# Altium schematic sheet RobotPower.SchDoc
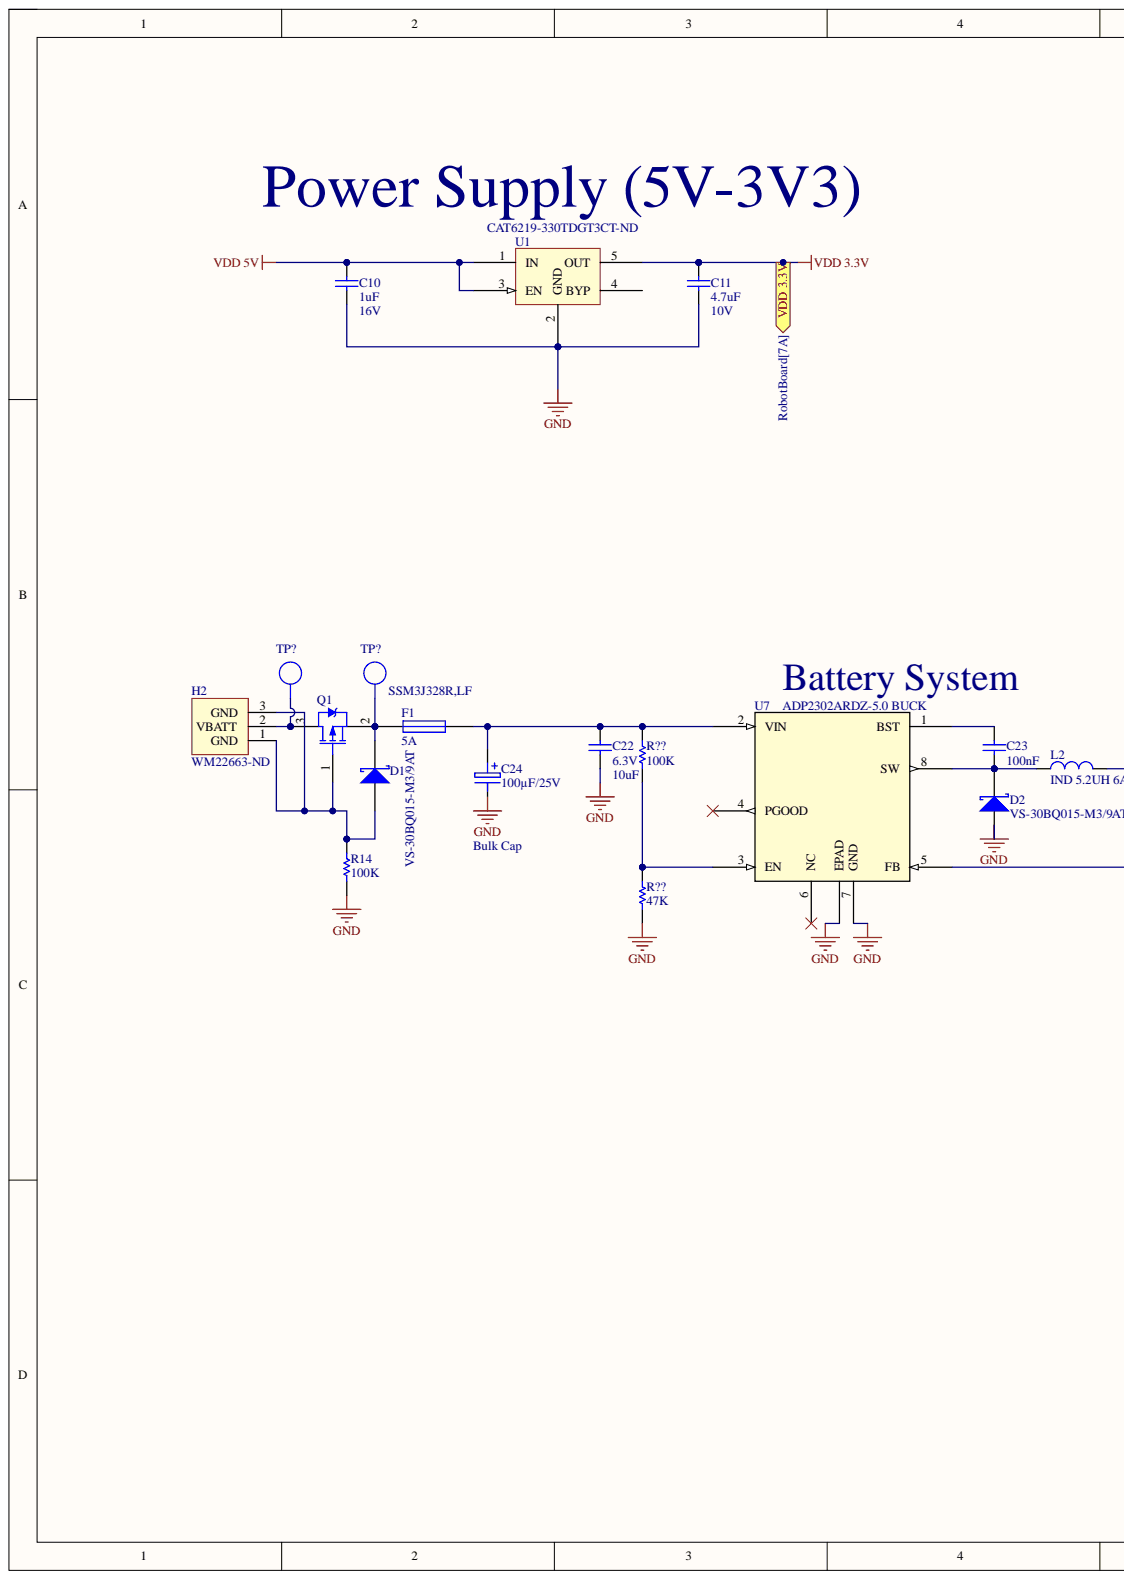
[diagram p0: RobotPower.SchDoc, left]
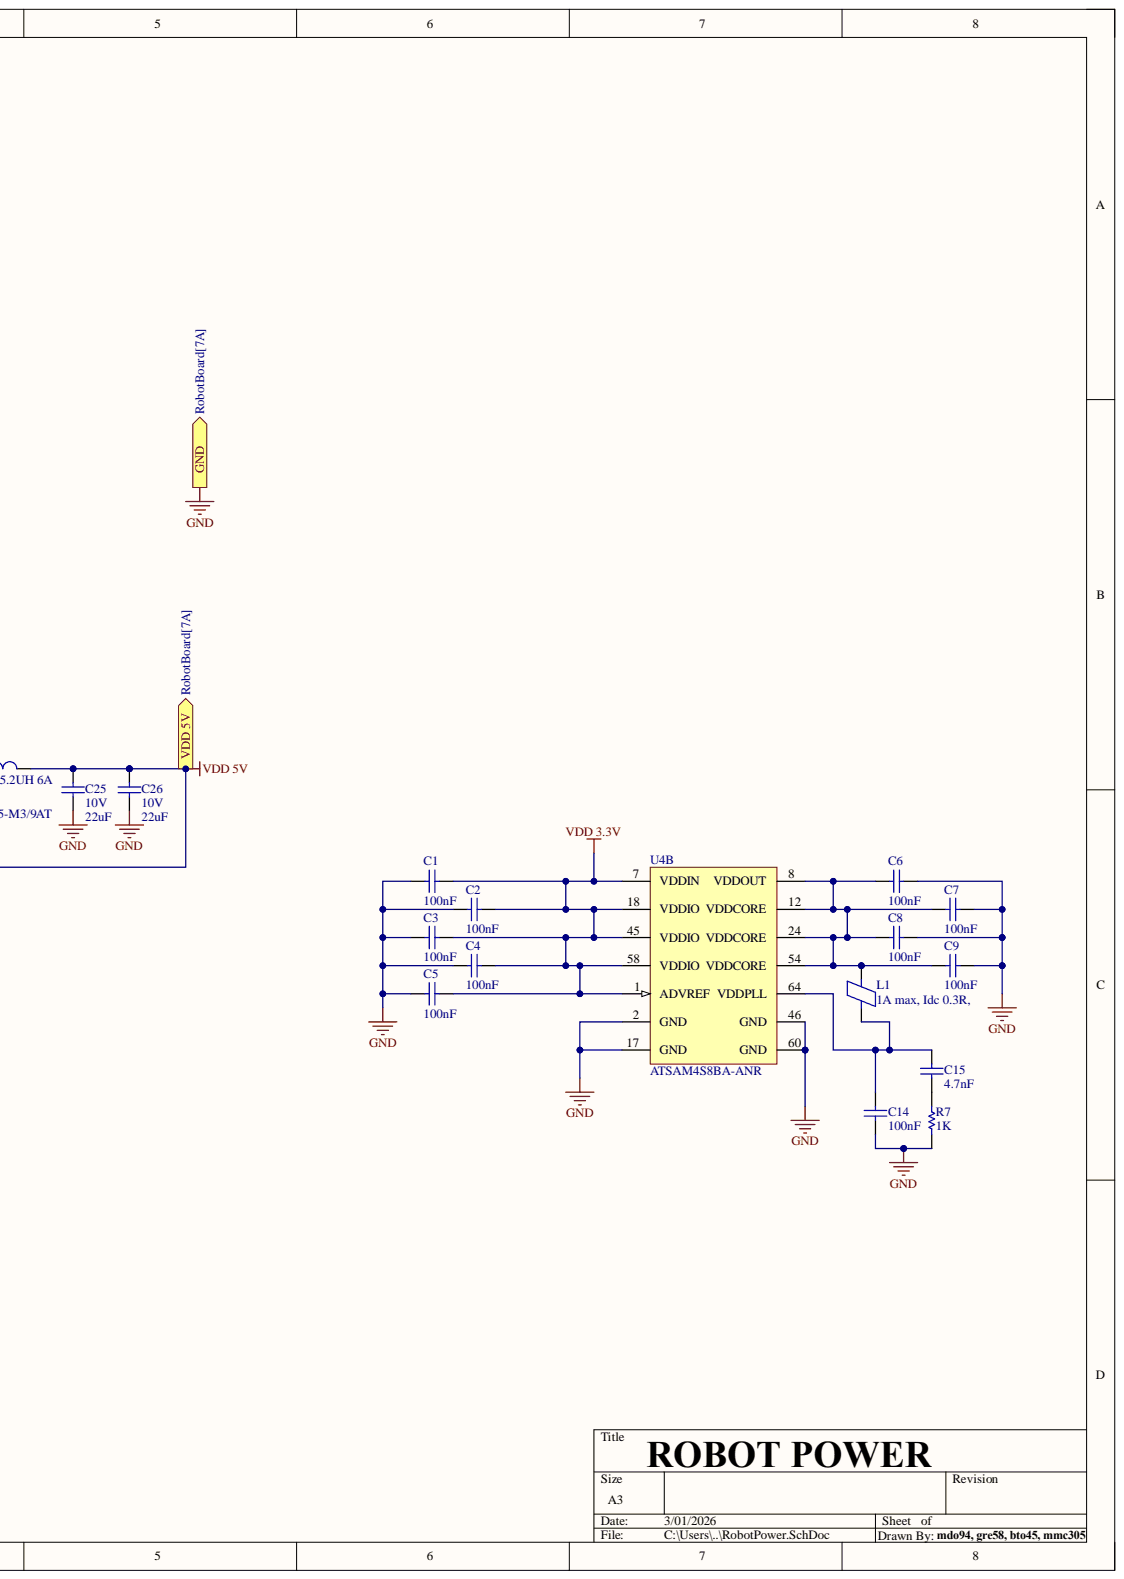
[diagram p1: RobotPower.SchDoc, right]

## Parts
C1  100nF  [CAPC1608L]
C2  100nF  [CAPC1608L]
C3  100nF  [CAPC1608L]
C4  100nF  [CAPC1608L]
C5  100nF  [CAPC1608L]
C6  100nF  [CAPC1608L]
C7  100nF  [CAPC1608L]
C8  100nF  [CAPC1608L]
C9  100nF  [CAPC1608L]
C10  1uF  Max Voltage: 16V  [CAPC1608L]
C11  4.7uF  Max Voltage: 10V  [CAPC1608N]
C14  100nF  [CAPC1608L]
C15  4.7nF  [CAPC1608L]
C22  10uF  Voltage - Rated: 6.3V  [CAPC1608L]
C23  100nF  [CAPC1608L]
C24  100µF/25V  [CAPAE6666X77M]
C25  22uF  Max Voltage: 10V  [1206 (3216 metric)]
C26  22uF  Max Voltage: 10V  [1206 (3216 metric)]
D1  VS-30BQ015-M3/9AT  [SMC]
D2  VS-30BQ015-M3/9AT  [SMC]
F1  5A  [RESC3216N]
H2  Supplier Part Number 1: WM22663-ND  [BATT_HEADER_3POS]  (hidden comment: Battery_HEADER_3pin)
L1  1A max, Idc 0.3R,  [INDC3216L]
L2  IND 5.2UH 6A  [INDUCTOR5u2]
Q1  SSM3J328R,LF  [SOT23_L]
R7  1K  [RESC1608L]
R14  100K  [RESC1608L]
R??  100K  [RESC1608L]
R??  47K  [RESC1608N]
TP?  TP  [TP_1206]  (no BOM)
TP?  TP  [TP_1206]  (no BOM)
U1  CAT6219-330TDGT3  Supplier Part Number: CAT6219-330TDGT3CT-ND  [SOT95P280X145-5N]
U4  ATSAM4S8BA-ANR  [LQFP64_L]  (part B)
U7  ADP2302ARDZ-5.0 BUCK  [RD_8_1]

## Ports
GND, VDD 3.3V, VDD 5V

## Nets
GND: C1.1 C2.1 C3.1 C4.1 C5.1 C6.2 C7.2 C8.2 C9.2 C10.2 C11.2 C14.2 C22.2 C24.1 C25.2 C26.2 D2.1 R7.2 R14.2 R??.2 U1.2 U4.2 U4.17 U4.46 U4.60 U7.7 U7.EPAD ; port GND
VDD 3.3V: C1.2 C2.2 C3.2 C4.2 C5.2 C11.1 U1.5 U4.1 U4.7 U4.18 U4.45 U4.58 ; port VDD 3.3V
VDD 5V: C10.1 C25.1 C26.1 L2.2 U1.1 U1.3 U7.5 ; port VDD 5V
NetC6_1: C6.1 C7.1 C8.1 C9.1 L1.1 U4.8 U4.12 U4.24 U4.54
NetC14_1: C14.1 C15.1 L1.2 U4.64
NetC15_2: C15.2 R7.1
NetC22_1: C22.1 C24.2 F1.2 R??.1 U7.2
NetC23_1: C23.1 U7.1
NetC23_2: C23.2 D2.2 L2.1 U7.8
NetD1_1: D1.1 H2.1 H2.3 Q1.1 R14.1
NetD1_2: D1.2 F1.1 Q1.2 TP?.1
NetH2_2: H2.2 Q1.3 TP?.1
NetR??_1: R??.1 R??.2 U7.3

Not connected: U1 4; U7 4 6

## Library data of the parts
C1-C9 C14 C23 = CC_100nF_0603: CAP CER 100nF X7R +-10% 50V 0603; Code_IPC: 1608 [0603]; Component Kind: Standard; Library Name: ECE_ComponentsAD23_2.IntLib; PackageReference: 0603; Signal Integrity: C0603; Simulation: CAP; Storage Location: PCB Assembly WS; Supplier: ECE Dept; Value: 100nF
C10 = CC_1uF_0603: CAP CER 1uF Y5V -20% +80% 16V 0603; Code_IPC: 1608 [0603]; Component Kind: Standard; Library Name: ECE_ComponentsAD23_2.IntLib; PackageReference: 0603; Signal Integrity: C0603; Simulation: CAP; Storage Location: PCB Assembly WS; Supplier: ECE Dept; Value: 1uF
C11 = CC_4.7uF_0603: CAP CER 4.7uF X5R +-20%  10V 0603; Note: Footprint corresponds to dimensions for bulk cassette packaging. This case size has tightened tolerances.; Code_IPC: 2012[0805]; Component Kind: Standard; Library Name: ECE_ComponentsAD23_2.IntLib; PackageReference: 0805; Simulation: CAP; Storage Location: PCB Assembly WS; Supplier: ECE Dept; Value: 4.7uF
C15 = CC_4.7nF_0603: CAP CER 4.7nF X7R +-10% 50V 0603; Code_IPC: 1608 [0603]; Component Kind: Standard; Library Name: ECE_ComponentsAD23_2.IntLib; PackageReference: 0603; Signal Integrity: C0603; Simulation: CAP; Storage Location: PCB Assembly WS; Supplier: ECE Dept; Value: 4.7nF
C22 = CC_10uF_0603: CAP CER 10UF X5R +-20% 6V3 0603; Component Kind: Standard; Library Name: ECE_ComponentsAD23_2.IntLib; Signal Integrity: C0805; Simulation: CAP; Storage Location: PCB Assembly WS; Supplier: ECE Dept; Value: 10uF
C24 = CA_100uF_25V: CAP ALUM 100UF 25V 20% SMD; Manufacturer: Nichicon; Manufacturer Part Number: UWT1E101MCL1GS; Ripple Current: 91mA; Capacitance: 100µF/25V; Component Kind: Standard; Height - Seated (Max): 0.303" (7.70mm); Library Name: ECE_ComponentsAD23_2.IntLib; Mounting Type: Surface Mount; Operating Temperature: -55°C ~ 105°C; Package / Case: Radial, Can - SMD; Size / Dimension: 0.248" Dia (6.30mm); Supplier 1: Digi-Key; Supplier Part Number 1: 493-2190-1-ND
C25 C26 = CC_22uF_1206: CAP CER 22uF Y5V -20% +80% 10V 1206; Component Kind: Standard; Dielectric: Y5V; Library Name: ECE_ComponentsAD23_2.IntLib; Simulation: CAP; Storage Location: PCB Assembly WS; Supplier: ECE Dept; Value: 22uF
D1 D2 = DS_VS-30BQ015-M3: DIODE, SCHOTTKY, 3A, 15V, DO-214AB / SMC; Manufacturer: Vishay Semiconductors; Forward Voltage: 350mV; Max Forward Surge Current (Ifsm): 650A; Peak Reverse Current: 4mA; Average Rectified Current: 3A; Component Kind: Standard; ComponentLink1Description: https://datasheet.ciiva.com/36181/vs-30bq015-m3-9at-36181258.pdf; ComponentLink1URL: https://datasheet.ciiva.com/36181/vs-30bq015-m3-9at-36181258.pdf; Library Name: ECE_ComponentsAD23_2.IntLib; Manufacturer Part Number: VS-30BQ015-M3/9AT; Max Reverse Voltage (DC): 15V; Supplier: Digi-Key; Supplier 1: Digi-Key; Supplier 2: Element14; Supplier Part Number: VS-30BQ015-M3/9AT-ND; Supplier Part Number 1: VS-30BQ015-M3/9ATGIDKR-ND; Supplier Part Number 2: 2628288
F1 = FS_Fuse_5.0A: Fuse 5.0A Fast Acting 1206 SMD; Manufacturer: Bel TRP; Manufacturer Part Number: C1F5; Approvals: CE,cURus; DC Cold Resistance: 19mR; Fuse Type: Board Mount (Cartridge Style Excluded); Voltage Rating: 125V; Voltage Rating (DC): 63V; Case Code (Imperial): 1206; Case Code (Metric): 3216; Case/Package: 1206; Component Kind: Standard; ComponentLink1Description: http://www.farnell.com/datasheets/2571625.pdf; ComponentLink1URL: http://www.farnell.com/datasheets/2571625.pdf; ComponentLink2Description: http://belfuse.com/pdfs/C1F.pdf; ComponentLink2URL: http://belfuse.com/pdfs/C1F.pdf; ComponentLink3Description: http://www.mouser.com/ds/2/643/C1F-594910.pdf; ComponentLink3URL: http://www.mouser.com/ds/2/643/C1F-594910.pdf; ComponentLink4Description: https://belfuse.com/resources/StewartConnector/C1F.pdf; ComponentLink4URL: https://belfuse.com/resources/StewartConnector/C1F.pdf; Contact Plating: Nickel,Tin; Current Rating: 5A; Length: 0.126inch; Library Name: ECE_ComponentsAD23_2.IntLib; Max Operating Temperature: 125°C; Min Operating Temperature: -55°C; Mount: Surface Mount; Number of Pins: 2; Packaging: Tape and Reel; Pricing 1: 1=0.32, 5=0.312, 10=0.298, 50=0.2662, 100=0.2479, 250=0.22032, 500=0.1836, 1000=0.17442 (USD); Stock 1: 725; Supplier 1: Digi-Key; Supplier 2: Element14; Supplier Part Number 1: 507-1886-1-ND; Supplier Part Number 2: 2848595; Value: 5A; Voltage Rating (AC): 125V; Width: 0.062inch
H2 = Battery_HEADER_3pin: 3Pin Header 2.54mm spacing  Digikey #WM22663-ND; Component Kind: Standard; Library Name: ENCE461AD23.IntLib; Supplier 1: Digi-Key
L1 = IN_Ferrite-Bead: Ferrite Bead, Rdc 0.3R, Z100MHZ 600R, Imax 1A, SMD 1206; Manufacturer: FAIR-RITE; Manufacturer Part Number: 2512066017Y1; DC Current Rating: 1; DC Resistance Max: 0.08; Ferrite Case Style: 1206 ^3216 Metric]; Frequency Range: 50MHz to 1; Impedance: 600; Impedance Tolerance: ± 25%; Component Kind: Standard; Ferrite Mounting: SMD; Library Name: ECE_ComponentsAD23_2.IntLib; Manufacturer PN: 2512066017Y1; Signal Integrity: Inductor Isolated; Storage Location: PCB Assembly WS; Supplier: ECE Dept; Supplier 1: Farnell; Supplier Part Number 1: 1612060; Value: 1A max, Idc 0.3R,
L2 = CDRH104RNP-5R2NC: FIXED IND 5.2UH 6A 22 MOHM SMD; Manufacturer: Sumida America Components Inc.; Current - Saturation: 5.6A; DC Resistance (DCR): 22 mOhm Max; Material - Core: Ferrite; Shielding: Shielded; Tolerance: ±30%; Category: Inductors, Coils, Chokes; Component Kind: Standard; ComponentLink1Description: http://products.sumida.com/products/pdf/CDRH104R.pdf; ComponentLink1URL: http://products.sumida.com/products/pdf/CDRH104R.pdf; Frequency - Self Resonant: -; Height - Seated (Max): 0.157" (4.00mm); Library Name: ENCE461AD23.IntLib; Manufacturer Part Number: CDRH104RNP-5R2NC; Mounting Type: Surface Mount; Operating Temperature: -40°C ~ 100°C; Package / Case: Nonstandard; Packaging: Cut Tape (CT); Part Status: Active; Pricing 1: 1=2.9, 10=2.678, 100=1.8743, 500=1.5173 (NZD); Q @ Freq: -; Ratings: -; RoHS: RoHS Compliant; Size / Dimension: 0.402" L x 0.394" W (10.20mm x 10.00mm); Stock 1: 3849; Supplier 1: Digi-Key; Supplier Device Package: -; Supplier Part Number 1: 308-2280-1-ND; Type: -
Q1 = TM_SSM3J328R: MOSFET P-CH 20V 6A SOT23-3; Manufacturer: Toshiba; Drain to Source Breakdown Voltage: -20V; Drain to Source Resistance: 47.4mR; Element Configuration: Single; FET Feature: Logic Level Gate, 1.5V Drive; FET Type: MOSFET P-Channel, Metal Oxide; Gate Charge (Qg) @ Vgs: 12.8nC @ 4.5V; Gate to Source Voltage (Vgs): 8V; Input Capacitance: 840pF; Input Capacitance (Ciss) @ Vds: 840pF @ 10V; Max Power Dissipation: 1W; Number of Channels: 1; Power Dissipation: 2W; Rds On Max: 29.8mR; Turn-Off Delay Time: 107ns; Turn-On Delay Time: 32ns; Vgs(th) (Max) @ Id: 1V @ 1mA; Case/Package: SOT-23-3; Component Kind: Standard; ComponentLink1Description: https://datasheet.ciiva.com/11158/ssm3j328r-datasheet-en-20140301-11158652.pdf; ComponentLink1URL: https://datasheet.ciiva.com/11158/ssm3j328r-datasheet-en-20140301-11158652.pdf; Continuous Drain Current (ID): 6A; Current - Continuous Drain (Id) @ 25° C: 6A; Drain to Source Voltage (Vdss): 20V; Library Name: ECE_ComponentsAD23_2.IntLib; Manufacturer Part Number: SSM3J328R,LF; Max Operating Temperature: 150°C; Min Operating Temperature: -55°C; Mount: Surface Mount; Number of Elements: 1; Number of Pins: 3; Package / Case: SOT-23-3 Flat Leads; Package Quantity: 3000; Packaging: Tape and Reel; Power - Max: 1W; Pricing: 1=0.79, 10=0.658, 25=0.5772, 100=0.4939, 250=0.42836, 500=0.36284, 1000=0.27972 (NZD); Radiation Hardening: No; Storage Location: PCB Assembly WS; Supplier: Digi-Key; Supplier 1: Digi-Key; Supplier Device Package: SOT-23F; Supplier Part Number: SSM3J328RLFDKR-ND; Supplier Part Number 1: SSM3J328RLFDKR-ND
R7 = RS_1K: RES 1.0K OHM 1/10W 5% 0603 SMD; Component Kind: Standard; Library Name: ECE_ComponentsAD23_2.IntLib; Signal Integrity: Res; Simulation: RESISTOR; Storage Location: PCB Assembly WS; Supplier: ECE Dept; Value: 1K
R14 R?? = RS_100K: RES 100K OHM 1/10W 5% 0603 SMD; Component Kind: Standard; Library Name: ECE_ComponentsAD23_2.IntLib; Signal Integrity: Res; Simulation: RESISTOR; Storage Location: PCB Assembly WS; Supplier: ECE Dept; Value: 100K
R?? = RS_47K: RES 47.0K OHM 1/10W 5% 0603 SMD; Component Kind: Standard; Library Name: ECE_ComponentsAD23_2.IntLib; Signal Integrity: Res; Simulation: RESISTOR; Storage Location: PCB Assembly WS; Supplier: ECE Dept; Value: 47K
TP? TP? = TP_1206: Test-Point 3.3x1.6x2.1mm SMD; Manufacturer: Harwin Inc; Manufacturer Part Number: S1751-46R; Library Name: ECE_ComponentsAD23_2.IntLib; Mounting Type: Surface Mount; Storage Location: ProtoPlace; Supplier: ECE Dept; Supplier 1: Digi-Key; Supplier 2: Farnell; Supplier Part Number 1: 952-1478-2-ND; Supplier Part Number 2: 1826282; Type: PC Test Point; Value: TP
U1 = SV_CAT6219-330TDGT3: IC REG LIN 3.3V 500MA TSOT23-5; Voltage - Input (Max): 5.5V; Voltage Dropout (Max): 0.5V @ 500mA; Base Part Number: CAT6219; Component Kind: Standard; ComponentLink1Description: http://www.onsemi.com/pub/Collateral/CAT6219-D.PDF; ComponentLink1URL: http://www.onsemi.com/pub/Collateral/CAT6219-D.PDF; ComponentLink2Description: CAT6219 Datasheet; ComponentLink2URL: \\maxwell\elecapp\AltiumDesigner\Libraries\DatasheetsNew\ENCE461\CAT6219-D.PDF; Current - Output: 500mA; Library Name: ENCE461AD23.IntLib; Manufacturer Part Number: CAT6219-330TDGT3; Package / Case: SOT-23-5 Thin, TSOT-23-5; Supplier: Digi-Key; Supplier 1: Digi-Key; Supplier Device Package: TSOT-23-5; Voltage - Output (Min/Fixed): 3.3V
U4 = SE_ATSAM4S16BA-MU: IC MCU 32BIT 512KB FLASH 64LQFP; Manufacturer: Atmel; Connectivity: I²C, IrDA, Memory Card, SPI, SSC, UART/USART, USB; Core Processor: ARM® Cortex®-M4; Core Size: 32-Bit; Data Converters: A/D 11x12b, D/A 2x12b; Number of I/O: 47; Peripherals: Brown-out Detect/Reset, DMA, POR, PWM, WDT; Program Memory Size: 512KB (512K x 8); RAM Size: 128K x 8; Speed: 120MHz; Voltage - Supply (Vcc/Vdd): 1.62 V ~ 3.6 V; Code_JEDEC: MO-220VMMD-3; Component Kind: Standard; ComponentLink1Description: Manufacturer URL; ComponentLink1URL: http://www.atmel.com/; ComponentLink2Description: SAM4S Series Datasheet; ComponentLink2URL: http://www.atmel.com/dyn/resources/prod_documents/11100.pdf; ComponentLink3Description: SAM4S Series Summary; ComponentLink3URL: http://www.atmel.com/dyn/resources/prod_documents/11100S.pdf; Library Name: ECE_ComponentsAD23_2.IntLib; Manufacturer Part Number: ATSAM4S8BA-ANR; Package / Case: 64-LQFP; PackageDescription: 64-Pin Quad Flat No-Lead (QFN) Sawn, 9 x 9 x 1.0 mm Body, 0.5 mm Pitch, Exposed Pad; PackageReference: 64PS; PackageVersion: revJ, Jul-2007; Packaging: Cut Tape (CT); Program Memory Type: FLASH; Supplier 1: Digi-Key; Supplier Part Number 1: ATSAM4S8BA-ANRCT-ND
U7 = ADP2302ARDZ-5.0-R7: IC REG BUCK 5V 2A 8SOIC; Frequency - Switching: 700kHz; Output Type: Fixed; Vendor: Analog Devices Inc; Voltage - Input (Max): 20V; Component Kind: Standard; ComponentLink1Description: ADP2302ARDZ-5.0 Datasheet; ComponentLink1URL: \\maxwell\elecapp\AltiumDesigner\Libraries\DatasheetsNew\ENCE461\ADP2302_2303.pdf; Current - Output: 2A; Library Name: ENCE461AD23.IntLib; Manufacturer_Part_Number: ADP2302ARDZ-5.0-R7; Supplier 1: Digi-Key; Supplier Part Number 1: ADP2302ARDZ-5.0-R7CT-ND; Type: DEV; Value: Value; Voltage - Output (Min/Fixed): 5V
